# Altium schematic sheet SIM.SchDoc
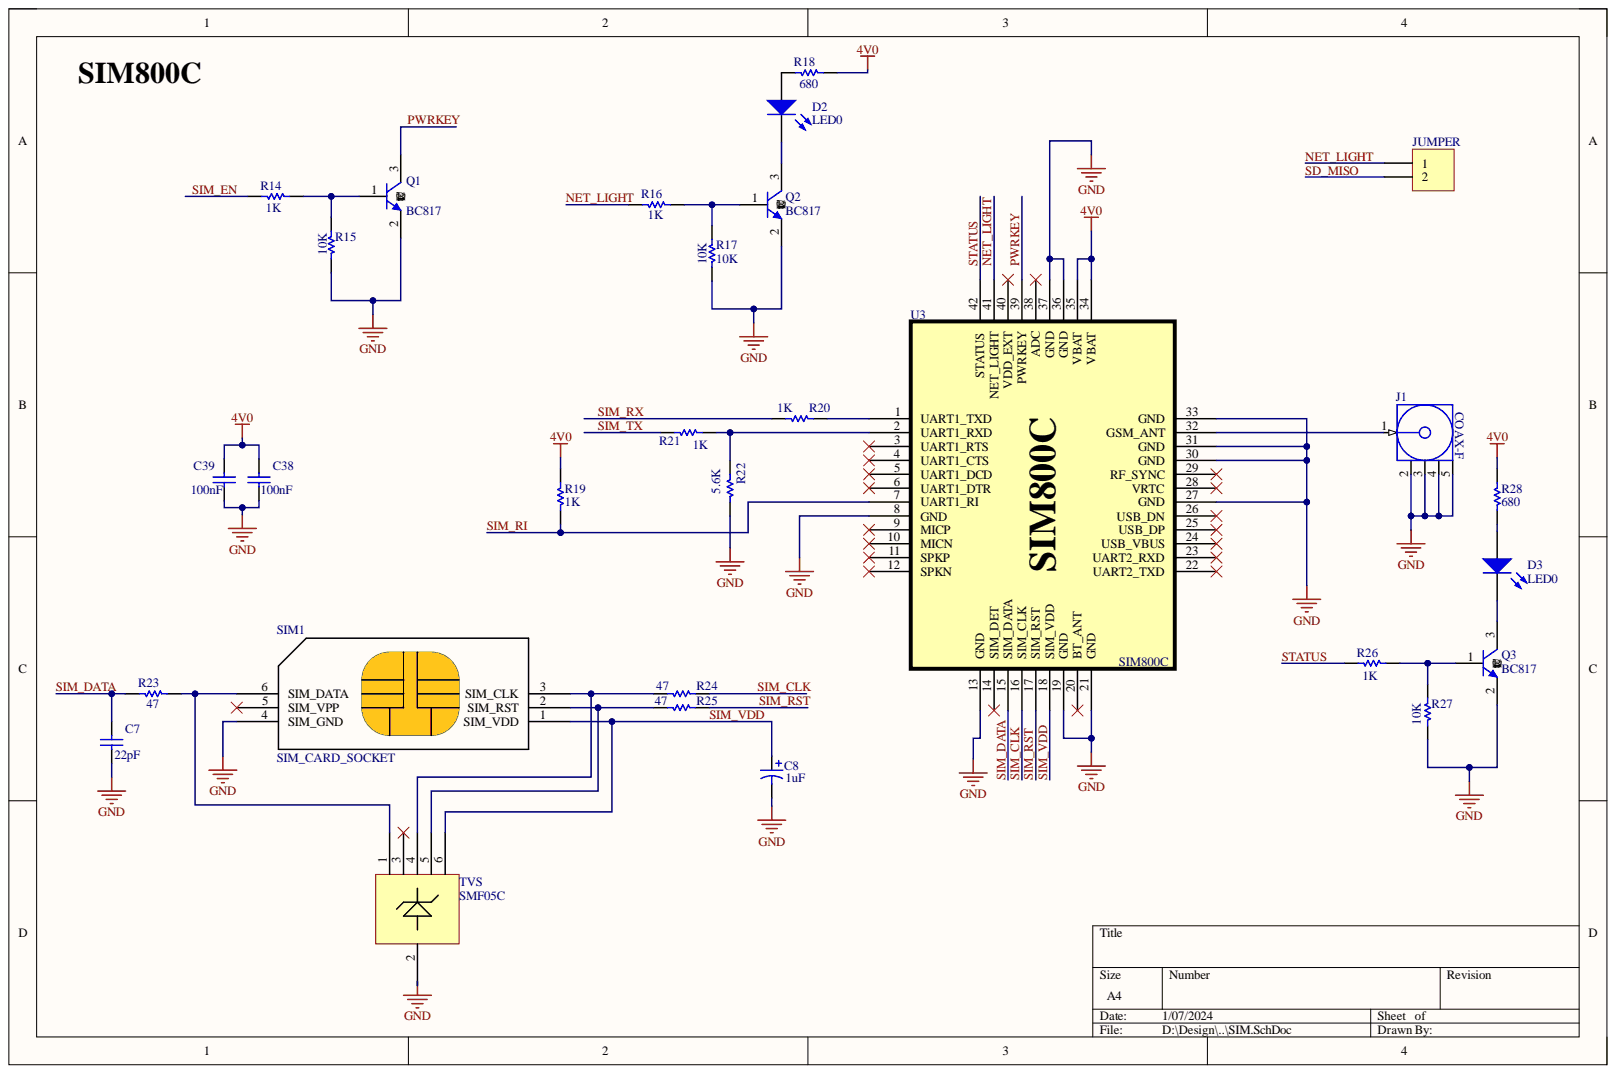
[diagram p0: SIM.SchDoc]

## Parts
C7  22pF  [SMD_805]
C8  1uF  [CAP_SMD_A]
C38  100nF  [SMD_805]
C39  100nF  [SMD_805]
D2  LED0  [SMD_805]
D3  LED0  [SMD_805]
J1  COAX-F  [SMA]
JUMPER  (no value)  [HDR1X2]
Q1  BC817  [SOT23]
Q2  BC817  [SOT23]
Q3  BC817  [SOT23]
R14  1K  [SMD_805]
R15  10K  [SMD_805]
R16  1K  [SMD_805]
R17  10K  [SMD_805]
R18  680  [SMD_805]
R19  1K  [SMD_805]
R20  1K  [SMD_805]
R21  1K  [SMD_805]
R22  5.6K  [SMD_805]
R23  47  [SMD_805]
R24  47  [SMD_805]
R25  47  [SMD_805]
R26  1K  [SMD_805]
R27  10K  [SMD_805]
R28  680  [SMD_805]
SIM1  SIM_CARD_SOCKET  [SIM_CARD_HOLDER]
TVS  SMF05C  [SMF05C_6L]
U3  SIM800C  [SIM800C_3D]

## Nets
4V0: C38.2 C39.2 R18.2 R19.1 R28.2 U3.34(VBAT) U3.35(VBAT)
GND: C7.1 C8.2 C38.1 C39.1 J1.2 J1.3 J1.4 J1.5 Q1.2 Q2.2 Q3.2 R15.2 R17.2 R22.1 R27.2 SIM1.4(SIM_GND) TVS.2 U3.8(GND) U3.13(GND) U3.19(GND) U3.21(GND) U3.27(GND) U3.30(GND) U3.31(GND) U3.33(GND) U3.36(GND) U3.37(GND)
NET_LIGHT: JUMPER.1 R16.1 U3.41(NET_LIGHT)
PWRKEY: Q1.3 U3.39(PWRKEY)
SD_MISO: JUMPER.2
SIM_CLK: R24.2 U3.16(SIM_CLK)
SIM_DATA: C7.2 R23.1 U3.15(SIM_DATA)
SIM_EN: R14.1
SIM_RI: R19.2 U3.7(UART1_RI)
SIM_RST: R25.2 U3.17(SIM_RST)
SIM_RX: R20.2
SIM_TX: R21.2
SIM_VDD: C8.1 SIM1.1(SIM_VDD) TVS.6 U3.18(SIM_VDD)
STATUS: R26.1 U3.42(STATUS)
NetD2_1: D2.1 R18.1
NetD2_2: D2.2 Q2.3
NetD3_1: D3.1 R28.1
NetD3_2: D3.2 Q3.3
NetJ1_1: J1.1 U3.32(GSM_ANT)
NetQ1_1: Q1.1 R14.2 R15.1
NetQ2_1: Q2.1 R16.2 R17.1
NetQ3_1: Q3.1 R26.2 R27.1
NetR20_1: R20.1 U3.1(UART1_TXD)
NetR21_1: R21.1 R22.2 U3.2(UART1_RXD)
NetR23_2: R23.2 SIM1.6(SIM_DATA) TVS.1
NetR24_1: R24.1 SIM1.3(SIM_CLK) TVS.4
NetR25_1: R25.1 SIM1.2(SIM_RST) TVS.5

Not connected: SIM1 5(SIM_VPP); TVS 3; U3 3(UART1_RTS) 4(UART1_CTS) 5(UART1_DCD) 6(UART1_DTR) 9(MICP) 10(MICN) 11(SPKP) 12(SPKN) 14(SIM_DET) 20(BT_ANT) 22(UART2_TXD) 23(UART2_RXD) 24(USB_VBUS) 25(USB_DP) 26(USB_DN) 28(VRTC) 29(RF_SYNC) 38(ADC) 40(VDD_EXT)

## Library data of the parts
C7 C38 C39 = Cap: Capacitor; Component Kind: Standard; LatestRevisionDate: 17-Jul-2002; LatestRevisionNote: Re-released for DXP Platform.; Library Name: Miscellaneous Devices.IntLib; PackageReference: RAD-0.3; Published: 8-Jun-2000; Signal Integrity: Cap; Simulation: CAP; Publisher: Altium Limited
  C7: Value: 22pF
  C38 C39: Value: 100nF
C8 = Cap Pol1: Polarized Capacitor (Radial); Value: 1nF; Component Kind: Standard; LatestRevisionDate: 17-Jul-2002; LatestRevisionNote: Re-released for DXP Platform.; Library Name: Miscellaneous Devices.IntLib; PackageReference: RB7.6-15; Published: 8-Jun-2000; Signal Integrity: Cap Pol; Simulation: CAP; Publisher: Altium Limited
D2 D3 = LED0: Typical INFRARED GaAs LED; Component Kind: Standard; LatestRevisionDate: 08-Jul-2005; LatestRevisionNote: Mech Layer 1 removed.; Library Name: Miscellaneous Devices.IntLib; PackageReference: LED-0; Published: 8-Jun-2000; Simulation: LED0; Publisher: Altium Limited
J1 = COAX-F: RF Coaxial PCB Connector, MCX; Thru-Hole, Right-Angle Mount Socket, Blunt Post Terminal, 50 Ohm Impedance; DrillSize: Corresponds to nominal value.; PCB_Layout: Complies with manufacturer's recommendation.; Component Kind: Standard; ComponentLink1Description: Manufacturer Link; ComponentLink1URL: http://www.amphenolrf.com/; DatasheetDocument: 30-May-2003; LatestRevisionDate: 15-Jul-2004; LatestRevisionNote: Component Replaced.; Library Name: Miscellaneous Connectors.IntLib; PackageDocument: 04-Jul-1999; PackageReference: 919-119J-51AX; Published: 15-Jul-2004; Publisher: Altium Limited
JUMPER = Header 2: Header, 2-Pin; Component Kind: Standard; LatestRevisionDate: 17-Jul-2002; LatestRevisionNote: Re-released for DXP Platform.; Library Name: 5665281587.intlib; Signal Integrity: Connector; Publisher: Altium Limited
Q1-Q3 = 2N3904: NPN General Purpose Amplifier; Code_JEDEC: TO-92A; Component Kind: Standard; ComponentLink1Description: Manufacturer Link; ComponentLink1URL: http://www.fairchildsemi.com/; ComponentLink2Description: Datasheet; ComponentLink2URL: http://www.fairchildsemi.com/ds/2N%2F2N3904.pdf; DatasheetVersion: 1997; LatestRevisionDate: 15-Jan-2003; LatestRevisionNote: PCB Footprint 'BCY-W3/D4.7' replaced; Library Name: Miscellaneous Devices.IntLib; PackageReference: TO-92A; PackageVersion: Sep-1998; Published: 8-Jun-2000; Signal Integrity: 2N3904; Simulation: 2N3904; Publisher: Altium Limited
R14-R28 = Res1: Resistor; Component Kind: Standard; LatestRevisionDate: 17-Jul-2002; LatestRevisionNote: Re-released for DXP Platform.; Library Name: Miscellaneous Devices.IntLib; PackageReference: AXIAL-0.3; Published: 8-Jun-2000; Signal Integrity: Res; Simulation: RESISTOR; Publisher: Altium Limited
  R14 R16 R19-R21 R26: Value: 1K
  R15 R17 R27: Value: 10K
  R18 R28: Value: 680
  R22: Value: 5.6K
  R23-R25: Value: 47
TVS = TVS_ARRAY: Component Kind: Standard; Library Name: TVS_ARRAY.SchLib
SIM1 = SIM_CARD_SOCKET: Component Kind: Standard; Library Name: SIM_CARD_SOCKET.SchLib
U3 = SIM800C: Component Kind: Standard; Library Name: SIM800C.SchLib
